# Altium schematic sheet Communication.SchDoc
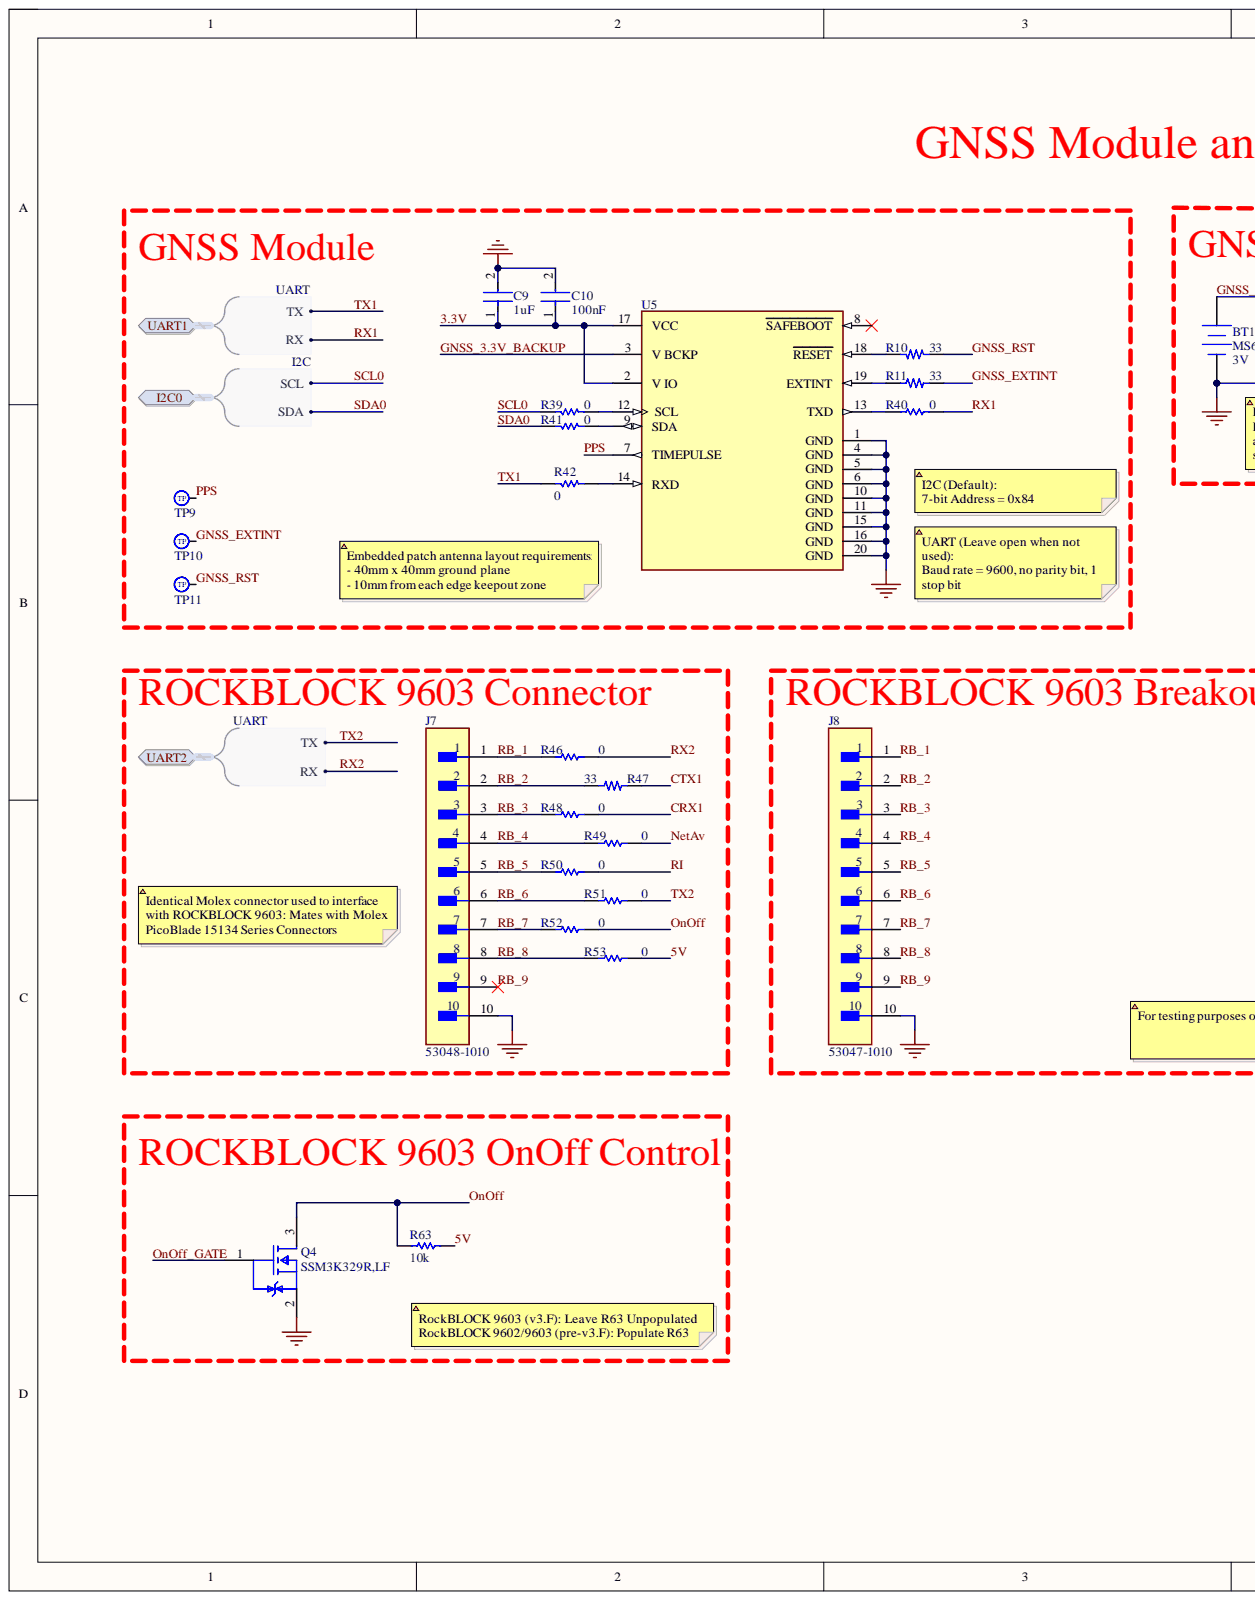
[diagram p0: Communication.SchDoc, left]
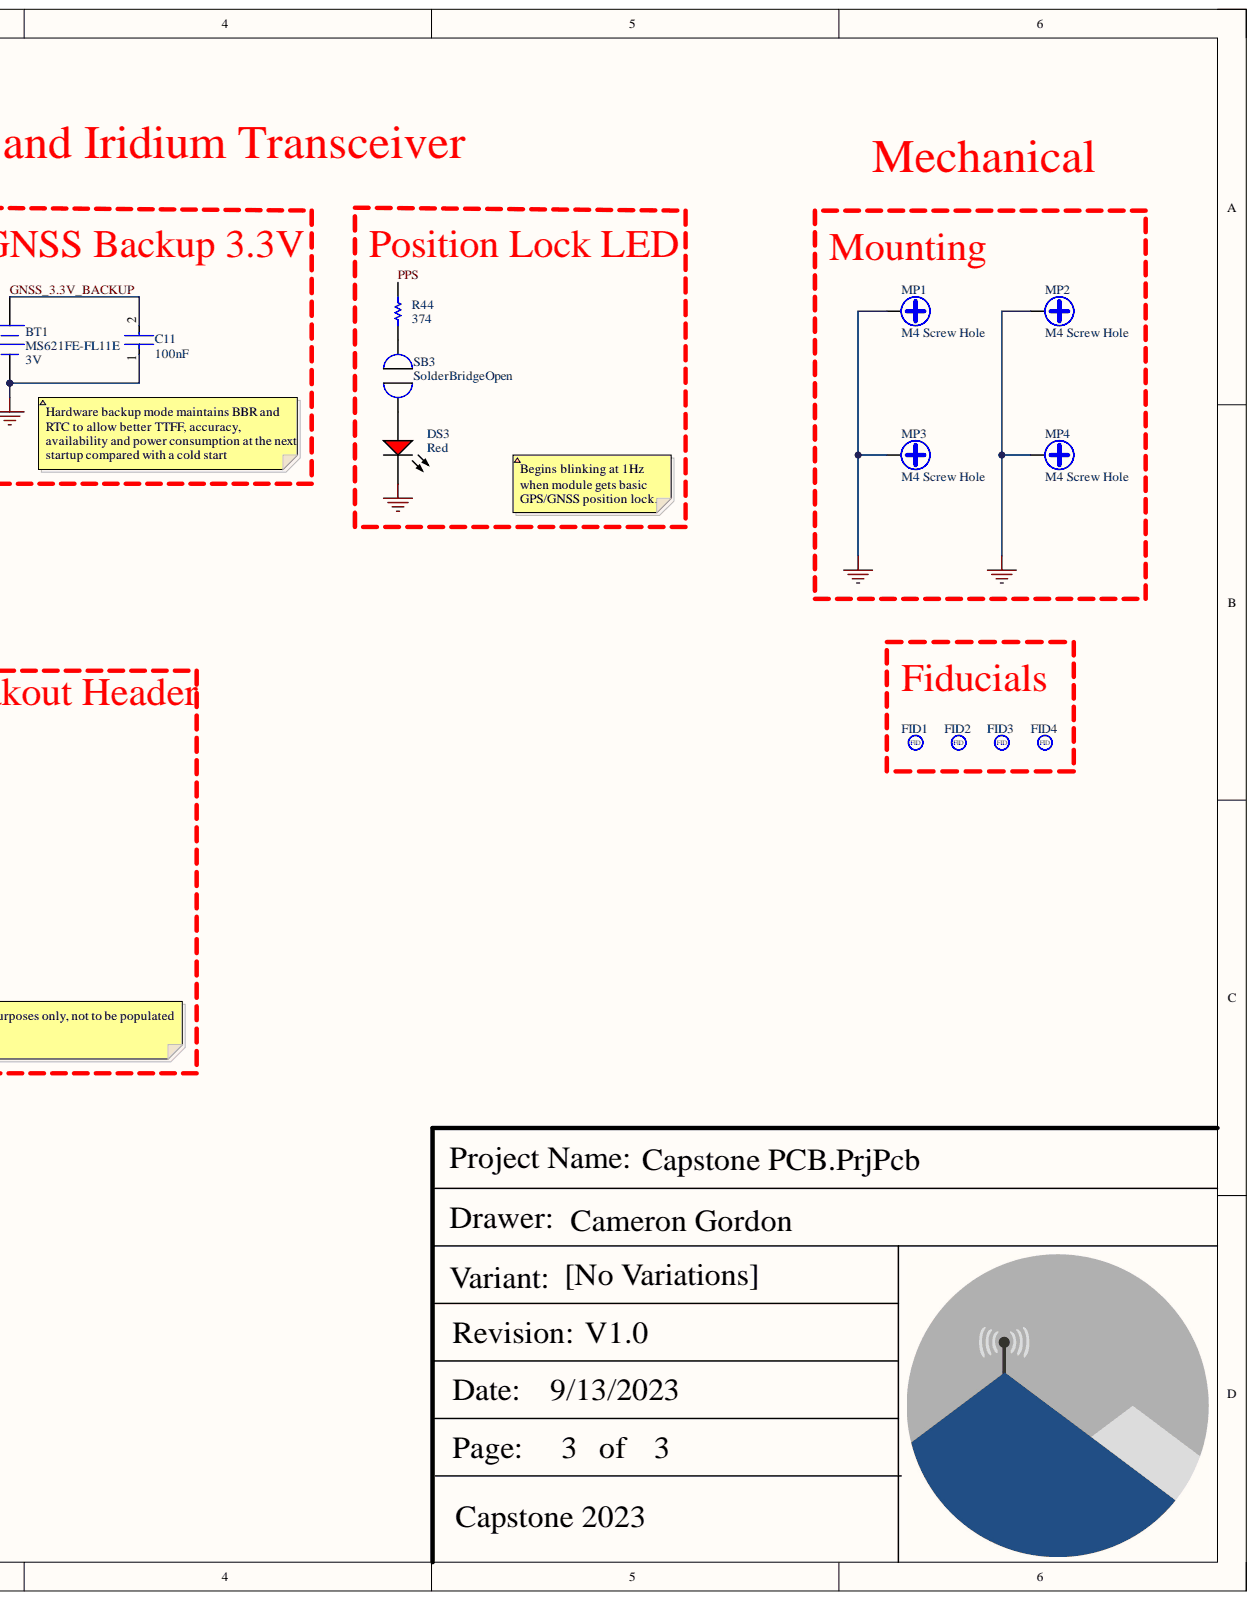
[diagram p1: Communication.SchDoc, right]

## Parts
BT1  MS621FE-FL11E  Output Voltage: 3V  [SEIK-MS621FE-FL11E-2_V]
C9  Capacitance: 1uF  [FP-CL10-IPC_C]  (hidden comment: CL10B105KP8NNNC)
C10  Capacitance: 100nF  [FP-CL10-IPC_C]  (hidden comment: CL10B104MB8NNNC)
C11  Capacitance: 100nF  [FP-CL10-IPC_C]  (hidden comment: CL10B104MB8NNNC)
DS3  Colour: Red  [LTST-C170KRKT_V]  (hidden comment: LTST-C170KRKT)
FID1  Fiducial  [40 mil Circular Test Point]
FID2  Fiducial  [40 mil Circular Test Point]
FID3  Fiducial  [40 mil Circular Test Point]
FID4  Fiducial  [40 mil Circular Test Point]
J7  53048-1010  [FP-53048-1010-MFG]
J8  53047-1010  [FP-53047-1010-MFG]
MP1  M4 Screw Hole  [New footprint 1]
MP2  M4 Screw Hole  [New footprint 1]
MP3  M4 Screw Hole  [New footprint 1]
MP4  M4 Screw Hole  [New footprint 1]
Q4  SSM3K329R,LF  [FP-2-3Z1A-MFG]
R10  CRGCQ0603J33R  [0603 Resistor]  (value not drawn)
R11  CRGCQ0603J33R  [0603 Resistor]  (value not drawn)
R39  RC0603JR-070RL  [RESC1608X55X25ML10T15]  (value not drawn)
R40  RC0603JR-070RL  [RESC1608X55X25ML10T15]  (value not drawn)
R41  RC0603JR-070RL  [RESC1608X55X25ML10T15]  (value not drawn)
R42  RC0603JR-070RL  [RESC1608X55X25ML10T15]  (value not drawn)
R44  value 374  [RESC2013X60X35ML10T20]  (hidden comment: RC0805FR-07374RL)
R46  RC0603JR-070RL  [RESC1608X55X25ML10T15]  (value not drawn)
R47  CRGCQ0603J33R  [0603 Resistor]  (value not drawn)
R48  RC0603JR-070RL  [RESC1608X55X25ML10T15]  (value not drawn)
R49  RC0603JR-070RL  [RESC1608X55X25ML10T15]  (value not drawn)
R50  RC0603JR-070RL  [RESC1608X55X25ML10T15]  (value not drawn)
R51  RC0603JR-070RL  [RESC1608X55X25ML10T15]  (value not drawn)
R52  RC0603JR-070RL  [RESC1608X55X25ML10T15]  (value not drawn)
R53  RC0603JR-070RL  [RESC1608X55X25ML10T15]  (value not drawn)
R63  Resistance: 10k  [RESC1609X55X30LL15T15]  (hidden comment: ERJ3EKF1002V)
SB3  SolderBridgeOpen  [SOLDERBRIDGEOPEN]
TP9  0.040" Circular Test Point  [40 mil Circular Test Point]  (value not drawn)
TP10  0.040" Circular Test Point  [40 mil Circular Test Point]  (value not drawn)
TP11  0.040" Circular Test Point  [40 mil Circular Test Point]  (value not drawn)
U5  SAM-M10Q-00B  [FP-SAM-M10Q-00B-MFG]  (value not drawn)

## Ports
I2C0, I2C0.SCL, I2C0.SDA, UART1, UART1.RX, UART1.TX, UART2, UART2.RX, UART2.TX

## Nets
GND: BT1.2 C9.2 C10.2 C11.1 DS3.2 J7.10 J8.10 MP1.1 MP2.1 MP3.1 MP4.1 Q4.2 U5.1(GND) U5.4(GND) U5.5(GND) U5.6(GND) U5.10(GND) U5.11(GND) U5.15(GND) U5.16(GND) U5.20(GND)
3.3V: C9.1 C10.1 U5.2(V_IO) U5.17(VCC)
5V: R53.1 R63.1
CRX1: R48.1
CTX1: R47.2
GNSS_3.3V_BACKUP: BT1.1 C11.2 U5.3(V_BCKP)
GNSS_EXTINT: R11.2 TP10.1
GNSS_RST: R10.1 TP11.1
NetAv: R49.1
OnOff: Q4.3 R52.1 R63.2
OnOff_GATE: Q4.1
PPS: R44.1 TP9.1 U5.7(TIMEPULSE)
RB_1: J7.1 J8.1 R46.2
RB_2: J7.2 J8.2 R47.1
RB_3: J7.3 J8.3 R48.2
RB_4: J7.4 J8.4 R49.2
RB_5: J7.5 J8.5 R50.2
RB_6: J7.6 J8.6 R51.2
RB_7: J7.7 J8.7 R52.2
RB_8: J7.8 J8.8 R53.2
RB_9: J7.9 J8.9
RI: R50.1
RX1: R40.1 ; port UART1
RX2: R46.1 ; port UART2
SCL0: R39.1 ; port I2C0
SDA0: R41.1 ; port I2C0
TX1: R42.1 ; port UART1
TX2: R51.1 ; port UART2
NetDS3_1: DS3.1 SB3.1
NetR10_2: R10.2 U5.18(RESET)
NetR11_1: R11.1 U5.19(EXTINT)
NetR39_2: R39.2 U5.12(SCL)
NetR40_2: R40.2 U5.13(TXD)
NetR41_2: R41.2 U5.9(SDA)
NetR42_2: R42.2 U5.14(RXD)
NetR44_2: R44.2 SB3.2

Not connected: U5 8(SAFEBOOT)

## Library data of the parts
BT1 = CMP-2000-05342-1: MS Lihium Rechargeable Battery, 3 V, -20 to 60 degC, Tray; Manufacturer: SeikoInstruments; Battery Size: 621; Brand: SeikoInstrumentsMicroEnergy; Capacity: 5.5mAh; Chemistry: Lithium; Unit Weight: 0.008113oz; Component Kind: Standard; Datasheet URL: http://datasheet.octopart.com/MS621FE-FL11E-Seiko-datasheet-5320152.pdf; Datasheet Version: Jan-08; Diameter: 6.8mm; Factory Pack Quantity: 100; Height: 2.1mm; Library Name: Altium Content Vault; Manufacturer URL: http://www.sii.co.jp/en/; Mounting Style: WithLeads; Orientation: Vertical; Packaging: Tray; Product Category: CoinCellBattery; Rechargeable/Non-Rechargeable: Rechargeable; RoHS: NotApplicable; Series: MS; Termination Style: ThroughHole; Type: CoinCellBatterywithLeads
C9 = CMP-2000-06094-2: Cap Ceramic 1uF 10V X7R ±10% Pad SMD 0603 +125°C T/R; Case Code (Imperial): 0603; Case Code (Metric): 1608; Case/Package: 0603; Component Kind: Standard; Contact Plating: Tin; Depth: 0.8mm; Dielectric: X7R; Height: 0.8mm; Lead Free: Lead Free; Length: 1.6mm; Library Name: Altium Content Vault; Max Operating Temperature: 125°C; Min Operating Temperature: -55°C; Mount: Surface Mount; Package Quantity: 1; Packaging: Tape and Reel; Radiation Hardening: No; Thickness: 0.035inch; Tolerance: 10%; Voltage Rating: 10V; Voltage Rating (DC): 10V; Width: 0.031inch
C10 C11 = CMP-13271-001724-1: Cap Ceramic 100nF 50V X7R ±20% Pad SMD 0603 +125°C T/R; Case Code (Imperial): 0603; Case Code (Metric): 1608; Case/Package: 0603; Component Kind: Standard; Depth: 0.8mm; Dielectric: X7R; Height: 0.8mm; Lead Free: Lead Free; Length: 1.6mm; Library Name: Altium Content Vault; Max Operating Temperature: 125°C; Min Operating Temperature: -55°C; Mount: Surface Mount; Package Quantity: 1; Packaging: Tape and Reel; Radiation Hardening: No; Thickness: 0.035inch; Tolerance: 20%; Voltage Rating: 50V; Voltage Rating (DC): 50V; Width: 0.031inch
DS3 = CMP-2000-07593-1: LED Red, 75 mW, 54 mcd, -55 to 85 degC, 2-Pin SMD, RoHS, Tape and Reel; Manufacturer: Lite-On; Case\/Package: 0805; Dominant Wavelength: 631nm; Forward Current: 30mA; Forward Voltage: 2V; Lens Colour: Colorless; Lens Style: Rectangular; Lens Transparency: Clear; Luminous Intensity: 54mcd; Number of Elements: 1; Power Dissipation: 75mW; Test Current: 20mA; Viewing Angle: 130degrees; Wavelength: 639nm; Case Code (Imperial): 0805; Case Code (Metric): 2012; Component Kind: Standard; Datasheet URL: http://optoelectronics.liteon.com/upload/download/DS-22-99-0150/LTST-C170KRKT.pdf; Datasheet Version: Rev. D, 11/2014; Depth: 1.6mm; Height: 1.1mm; Illumination Colour: Red; Lead Free: LeadFree; Length: 3.2mm; Library Name: Altium Content Vault; Manufacturer URL: http://www.us.liteon.com/; Max Operating Temperature: 85degC; Min Operating Temperature: -55degC; Mount: SurfaceMount; Mounting Technology: SurfaceMount; Number of LEDs: 1; Number of Pins: 2; Package Description: 2-Pin Surface Mount Device, Body 2 x 1.25 mm; Package Quantity: 1; Package Reference: C170KRKT; Packaging: TapeandReel; Power Rating: 75mW; Radiation Hardening: No; RoHSCompliant: True; Width: 1.25mm
FID1-FID4 = CMP-00019-1: Component Kind: Standard; Description: Fiducial; Library Name: Northeastern Electric Racing
J7 = CMP-04776-000812-1: CONN HEADER R/A 10POS 1.25MM; Body Plating: Tin; Contact Resistance: 20mR; Current Rating: 1A; Gender: Male; Glow Wire Compliant: Non-compliant; Insulation Resistance: 100MR; Max Voltage Rating (AC): 125V; Number of Circuits: 10; Color: Natural; Component Kind: Standard; Contact Material: Bronze; Contact Plating: Tin; Depth: 5.5mm; Flammability Rating: UL94 V-0; Height: 3.5mm; Housing Color: Natural; Housing Material: Nylon; Lead Free: Lead Free; Lead Pitch: 1.25mm; Length: 14.25mm; Library Name: Altium Content Vault; Max Current Rating: 1A; Max Operating Temperature: 85°C; Min Operating Temperature: -40°C; Mount: Through Hole; Number of Contacts: 10; Number of Poles: 10; Number of Positions: 10; Number of Rows: 1; Orientation: Right Angle; Package Quantity: 2000; Packaging: Tray; Pitch: 1.25mm; Radiation Hardening: No; RoHS Compliant: Yes; Series: PicoBlade; Termination: Solder; Voltage Rating: 125V; Voltage Rating (AC): 125V; Voltage Rating (DC): 125V; Working Voltage: 125V
J8 = CMP-002-00108-1: Board Connector, 10 Contact(s), 1 Row(s), Male, Straight, 0.049 inch Pitch, Solder Terminal, Locking, White Insulator, Receptacle; Current Rating: 0A; Gender: Pin; Number of Contacts: 10; Voltage Rating: 0V; Component Kind: Standard; Mounting Type: Through Hole; Orientation: Vertical; Library Name: Northeastern Electric Racing
MP1-MP4 = CMP-00024-1: Plated hole for M4 mounting hardware; Component Kind: Standard; Library Name: Northeastern Electric Racing
Q4 = CMP-35916-000013-1: MOSFET N CH 30V 3.5A 2-3Z1A; Drain to Source Resistance: 290mR; Gate to Source Voltage (Vgs): 12V; Input Capacitance: 123pF; Max Power Dissipation: 1W; Rds On Max: 126mR; Case/Package: SOT-23-3; Component Kind: Standard; Continuous Drain Current (ID): 3.5A; Drain to Source Breakdown Voltage: 30V; Drain to Source Voltage (Vdss): 30V; Library Name: Altium Content Vault; Mount: Surface Mount; Package Quantity: 3000; Packaging: Tape and Reel; Power Dissipation: 1W
R10 R11 R47 = CMP-00148-2: Res Thick Film 0603 33 Ohm 5% 0.1W(1/10W) ±200ppm/C Pad SMD Automotive T/R; Power Rating: 100mW; Resistance: 33; Component Kind: Standard; Package: 0603; Tolerance: 5%; Library Name: Northeastern Electric Racing
R39-R42 R46 R48-R53 = CMP-2100-03667-1: Chip Resistor, 0 Ohm, +/- 5%, 0.1 W, -55 to 155 degC, 0603 (1608 Metric), RoHS, Tape and Reel; Manufacturer: Yageo; Power Rating: 100mW; Resistance: 0; Temperature Coefficient: 200ppm/degreesC; Voltage Rating: 50V; Case Code (Imperial): 0603; Case Code (Metric): 1608; Case/Package: 0603; Component Kind: Standard; Composition: ThickFilm; Contact Plating: Tin; Datasheet URL: http://www.yageo.com/NewPortal/yageodocoutput?fileName=/pdf/R-Chip/PYu-RC_Group_51_RoHS_L_5.pdf; Datasheet Version: Rev. 5, 10/2016; Depth: 0.8mm; Height: 0.45mm; Lead Free: LeadFree; Length: 1.6mm; Library Name: Altium Content Vault; Manufacturer URL: http://www.yageo.com/; Max Operating Temperature: 155degC; Military Standard: Not; Min Operating Temperature: -55degC; Number of Pins: 2; Package Description: 2-Pin Surface Mount Device, Body 1.6 x 0.8 mm; Package Quantity: 5000; Package Reference: 0603; Packaging: TapeandReel; Radiation Hardening: No; REACH SVHC: NoSVHC; RoHSCompliant: true; Termination: SMD/SMT; Tolerance: 5%; Voltage Rating (DC): 50V; Width: 0.8mm
R44 = CMP-2001-01105-1: Manufacturer: Yageo; Component Kind: Standard; Library Name: Altium Content Vault; Part Number: RC0805FR-07374RL
R63 = CMP-1012-00623-3: Chip Resistor, 10 KOhm, +/- 1%, 0.1 W, -55 to 155 degC, 0603 (1608 Metric), RoHS, Tape and Reel; Manufacturer: Panasonic; Case\/Package: 0603; Max Power Dissipation: 100mW; Temperature Coefficient: 100ppm\/degreesC; Voltage Rating: 75V; Case Code (Imperial): 0603; Case Code (Metric): 1608; Component Kind: Standard; Composition: ThickFilm; Contact Plating: Tin; Datasheet URL: https://industrial.panasonic.com/cdbs/www-data/pdf/RDA0000/AOA0000C304.pdf; Datasheet Version: 02/2017; Depth: 0.8mm; Height: 0.45mm; Lead Free: LeadFree; Length: 1.6mm; Library Name: Altium Content Vault; Manufacturer URL: http://www.panasonic.com/; Max Operating Temperature: 155degC; Military Standard: Not; Min Operating Temperature: -55degC; Mount: SurfaceMount; Mounting Technology: SurfaceMount; Number of Pins: 2; Number of Terminations: 2; Package Description: 2-Pin Surface Mount Device, Body 1.6 x 0.85 mm; Package Quantity: 1; Package Reference: 603; Packaging: TapeandReel; Power Rating: 100mW; Radiation Hardening: No; REACH SVHC: NoSVHC; RoHSCompliant: true; Termination: SMD/SMT; Tolerance: 1%; Voltage Rating (DC): 75V; Width: 0.031inch
TP9-TP11 = CMP-00017-2: Component Kind: Standard; Description: 0.040" Circular Test Point; Library Name: Northeastern Electric Racing
U5 = CMP-020-00023-2: M10 Gnss LGA Patch Antenna Mod; Max Supply Voltage: 3.3V; Min Supply Voltage: 1.8V; Case/Package: Custom; Component Kind: Standard; Library Name: Northeastern Electric Racing
SB3 = SolderBridgeOpen: Component Kind: Standard; Library Name: SolderBridge.SchLib
